# Altium schematic sheet 09)Battery_protection.SchDoc(09)Battery_protection)
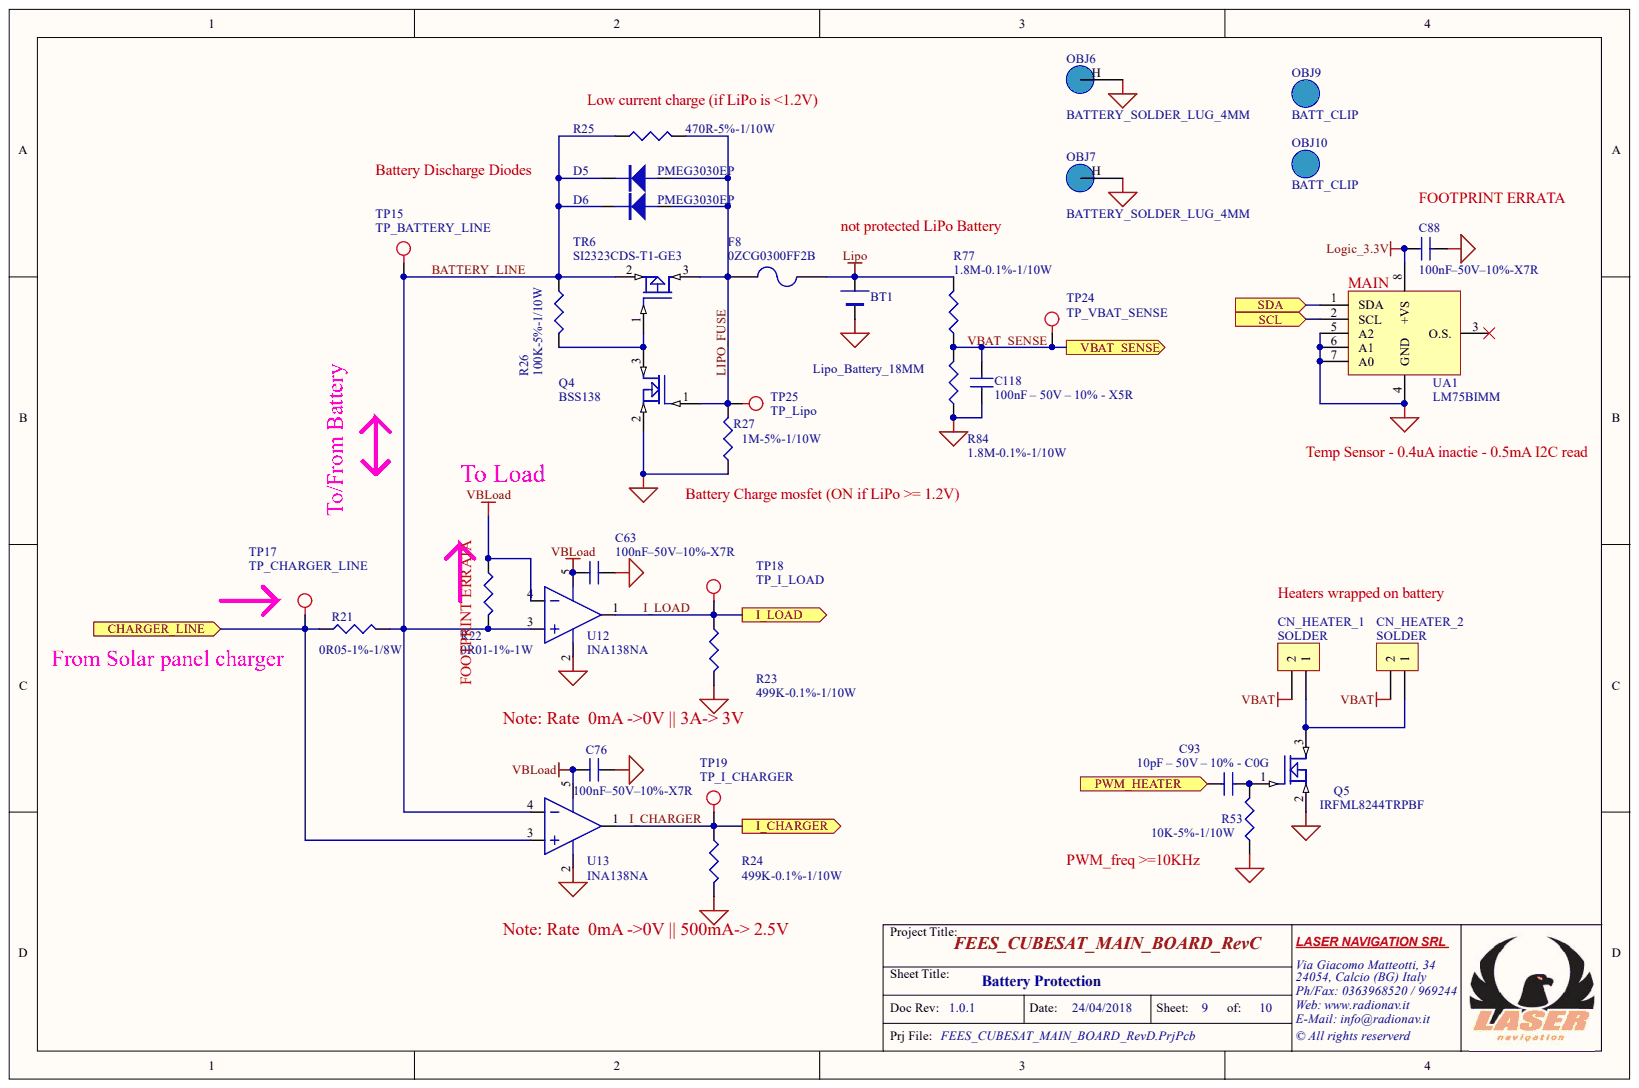
[diagram p0: 09)Battery_protection.SchDoc]

## Parts
BT1  Lipo_Battery_18MM  [BATTERY_18MM]
C63  100nF–50V–10%-X7R  [0603_CAP]
C76  100nF–50V–10%-X7R  [0603_CAP]
C88  100nF–50V–10%-X7R  [0603_CAP]
C93  10pF – 50V – 10% - C0G  [0603_CAP]
C118  100nF – 50V – 10% - X5R  [0603_CAP]
CN_HEATER_1  SOLDER  [STRIPM1X2V]
CN_HEATER_2  SOLDER  [STRIPM1X2V]
D5  PMEG3030EP  [SOD128]
D6  PMEG3030EP  [SOD128]
F8  0ZCG0300FF2B  [1812_FUSE_B]
OBJ6  BATTERY_SOLDER_LUG_4MM  [SOLDER_LUG_BATTERY_18MM]
OBJ7  BATTERY_SOLDER_LUG_4MM  [SOLDER_LUG_BATTERY_18MM]
OBJ9  BATT_CLIP  [OBJ_GENERIC]
OBJ10  BATT_CLIP  [OBJ_GENERIC]
Q4  BSS138  [SOT23]
Q5  IRFML8244TRPBF  [SOT23]
R21  0R05-1%-1/8W  [0805_RES]
R22  0R01-1%-1W  [2512_RES]
R23  499K-0.1%-1/10W  [0603_RES]
R24  499K-0.1%-1/10W  [0603_RES]
R25  470R-5%-1/10W  [0805_RES]
R26  100K-5%-1/10W  [0603_RES]
R27  1M-5%-1/10W  [0603_RES]
R53  10K-5%-1/10W  [0603_RES]
R77  1.8M-0.1%-1/10W  [0805_RES]
R84  1.8M-0.1%-1/10W  [0805_RES]
TP15  TP_BATTERY_LINE  [TP_SMD_PAD_1_5MM]
TP17  TP_CHARGER_LINE  [TP_SMD_PAD_1_5MM]
TP18  TP_I_LOAD  [TP_SMD_PAD_1_5MM]
TP19  TP_I_CHARGER  [TP_SMD_PAD_1_5MM]
TP24  TP_VBAT_SENSE  [TP_SMD_PAD_1_5MM]
TP25  TP_Lipo  [TP_SMD_PAD_1_5MM]
TR6  SI2323CDS-T1-GE3  [SOT23]
U12  INA138NA  [SOT23-5]
U13  INA138NA  [SOT23-5]
UA1  LM75BIMM  [TSSOP8]

## Ports
CHARGER_LINE, I_CHARGER, I_LOAD, PWM_HEATER, SCL, SDA, VBAT_SENSE

## Nets
GND: BT1.2 C63.2 C76.2 C88.1 C118.1 OBJ6.H OBJ7.H Q4.2 Q5.2 R23.2 R24.2 R27.1 R53.1 R84.1 U12.2 U13.2 UA1.4(GND) UA1.5(A2) UA1.6(A1) UA1.7(A0)
LIPO: BT1.1 F8.2 R77.2
LOGIC_3.3V: C88.2 UA1.8(+VS)
VBAT: CN_HEATER_1.2 CN_HEATER_2.2
VBLOAD: C63.1 C76.1 R22.1 U12.4 U12.5 U13.5
BATTERY_LINE: D5.2 D6.2 R21.2 R22.2 R25.1 R26.1 TP15.1 TR6.2 U12.3 U13.4
CHARGER_LINE: R21.1 TP17.1 U13.3 ; port CHARGER_LINE
I_CHARGER: R24.1 TP19.1 U13.1 ; port I_CHARGER
I_LOAD: R23.1 TP18.1 U12.1 ; port I_LOAD
LIPO_FUSE: D5.1 D6.1 F8.1 Q4.1 R25.2 R27.2 TP25.1 TR6.3
PWM_HEATER: C93.2 ; port PWM_HEATER
SCL: UA1.2(SCL) ; port SCL
SDA: UA1.1(SDA) ; port SDA
VBAT_SENSE: C118.2 R77.1 R84.2 TP24.1 ; port VBAT_SENSE
NetC93_1: C93.1 Q5.1 R53.2
NetCN_HEATER_1_1: CN_HEATER_1.1 CN_HEATER_2.1 Q5.3
NetQ4_3: Q4.3 R26.2 TR6.1

Not connected: UA1 3(O.S.)

## Library data of the parts
BT1 = BATTERY: 1.Part Number: NCR18650GA; 2.Manufacturer: Sanyo; 3.Description: Lipo Battery 1S 3500mA; 5.Mounting: Y; 4.Supplier: eu.nkon.nl; Component Kind: Standard; Description: Battery; Library Name: User Sch Library.SchLib
C63 C76 C88 = CAP: Non polarized capacitor; Component Kind: Standard; Library Name: User Sch Library.SchLib
C93 C118 = CAP: Non polarized capacitor; 5.Mounting: Y; Component Kind: Standard; Library Name: User Sch Library.SchLib
CN_HEATER_1 CN_HEATER_2 = CON2: Connector; 5.Mounting: SOLDERING; Component Kind: Standard; Library Name: User Sch Library.SchLib
D5 D6 = DIODE_SS34: Diode; 2.Manufacturer: NXP Semiconductors; 3.Description: DIODE SCHOTTKY 30V 3A SOD128; 5.Mounting: Y; 1.Part Number: PMEG3030EP; 4.Supplier: Digikey 1727-5324-1-ND; Component Kind: Standard; Library Name: User Sch Library.SchLib
F8 = FUSE2: Fuse; 2.Manufacturer: Bel Fuse Inc.; 3.Description: PTC Resettable Fuse 6V 3A Ih Surface Mount 1812 (4532 Metric), Concave; 5.Mounting: Y; 1.Part Number: 0ZCG0300FF2B; 4.Supplier: Digikey 507-1781-1-ND; Component Kind: Standard; Library Name: User Sch Library.SchLib
OBJ6 OBJ7 = OBJET_SHIELD: OBJET SHIELD; 2.Manufacturer: No Brand; 3.Description: Battery solder lug 100 x 4 mm ZHL 499; 5.Mounting: Y; 1.Part Number: BATTERY_SOLDER_LUG_4MM; 4.Supplier: Distrelec 	169-50-003; Component Kind: Standard; Library Name: User Sch Library.SchLib
OBJ9 OBJ10 = OBJET: GENERIC OBJECT; 1.Part Number: 1016-1; 2.Manufacturer: Keystone; 3.Description: UNIVERSAL BATT CONTACT SMT; 5.Mounting: Y; 4.Supplier: Digikey 36-1016-1-ND; Component Kind: Standard; Library Name: User Sch Library.SchLib
Q4 = MOSFET N: 2.Manufacturer: Fairchild/ON Semiconductor; 3.Description: MOSFET N-CH 50V 220MA SOT-23; 5.Mounting: Y; 1.Part Number: BSS138; 4.Supplier: Digikey BSS138CT-ND; Component Kind: Standard; Library Name: User Sch Library.SchLib
Q5 = MOSFET N: 2.Manufacturer: Infineon Technologies; 3.Description: MOSFET N-CH 25V 5.8A SOT23; 5.Mounting: Y; 1.Part Number: IRFML8244TRPBF; 4.Supplier: Digikey IRFML8244TRPBFCT-ND; Component Kind: Standard; Library Name: User Sch Library.SchLib
R21 = R: 1.Part Number: CRL0805-FW-R050ELF; 2.Manufacturer: Bourns Inc.; 3.Description: RES SMD 0.05 OHM 1% 1/10W 0805; 5.Mounting: Y; 4.Supplier: Digikey CRL0805-FW-R050ELFCT-ND; Component Kind: Standard; Library Name: User Sch Library.SchLib
R22 = R: 1.Part Number: CRF1206-FZ-R010ELF; 2.Manufacturer: Bourns Inc.; 3.Description: RES SMD 0.01 OHM 1% 1W 1206; 5.Mounting: Y; 4.Supplier: Digikey CRF1206-FZ-R010ELFCT-ND; Component Kind: Standard; Library Name: User Sch Library.SchLib
R23 R24 = R: 1.Part Number: RT0603BRE07499KL; 2.Manufacturer: Yageo; 3.Description: RES SMD 499K OHM 0.1% 1/10W 0603; 5.Mounting: Y; 4.Supplier: Digikey YAG4738CT-ND; Component Kind: Standard; Library Name: User Sch Library.SchLib
R25-R27 = R: Component Kind: Standard; Library Name: User Sch Library.SchLib
R53 = R: 5.Mounting: Y; Component Kind: Standard; Library Name: User Sch Library.SchLib
R77 R84 = R: 1.Part Number: CPF0805B1M8E; 2.Manufacturer: TE Connectivity Passive Product; 3.Description: RES SMD 1.8M OHM 0.1% 1/10W 0805; 5.Mounting: Y; 4.Supplier: Digikey A119973CT-ND; Component Kind: Standard; Library Name: User Sch Library.SchLib
TP15 TP17-TP19 TP24 TP25 = TP: Test Point; Component Kind: Standard; Library Name: User Sch Library.SchLib
TR6 = MOSFET P_1: 2.Manufacturer: Vishay Siliconix; 3.Description: MOSFET P-CH 20V 6A SOT-23; 5.Mounting: Y; 1.Part Number: SI2323CDS-T1-GE3; 4.Supplier: Digikey  SI2323CDS-T1-GE3CT-ND; Component Kind: Standard; Library Name: User Sch Library.SchLib
U12 U13 = INA138NA: Operational Amplifier; 1.Part Number: INA138NA/3K; 2.Manufacturer: Texas Instruments; 3.Description: IC CURRENT MONITOR 0.5% SOT23-5; 5.Mounting: Y; 4.Supplier: Digikey  296-17936-1-ND; Component Kind: Standard; Library Name: User Sch Library.SchLib
UA1 = LM75X: SENSOR TEMPERATURE I2C; 1.Part Number: LM75BIMM-3/NOPB; 2.Manufacturer: Texas Instruments; 3.Description: SENSOR TEMPERATURE I2C 8VSSOP; 5.Mounting: Y; 4.Supplier: Digikey LM75BIMM-3/NOPBCT-ND; Component Kind: Standard; Library Name: User Sch Library.SchLib
